# Altium schematic sheet EstmetSquematic.SchDoc(EstmetSquematic)
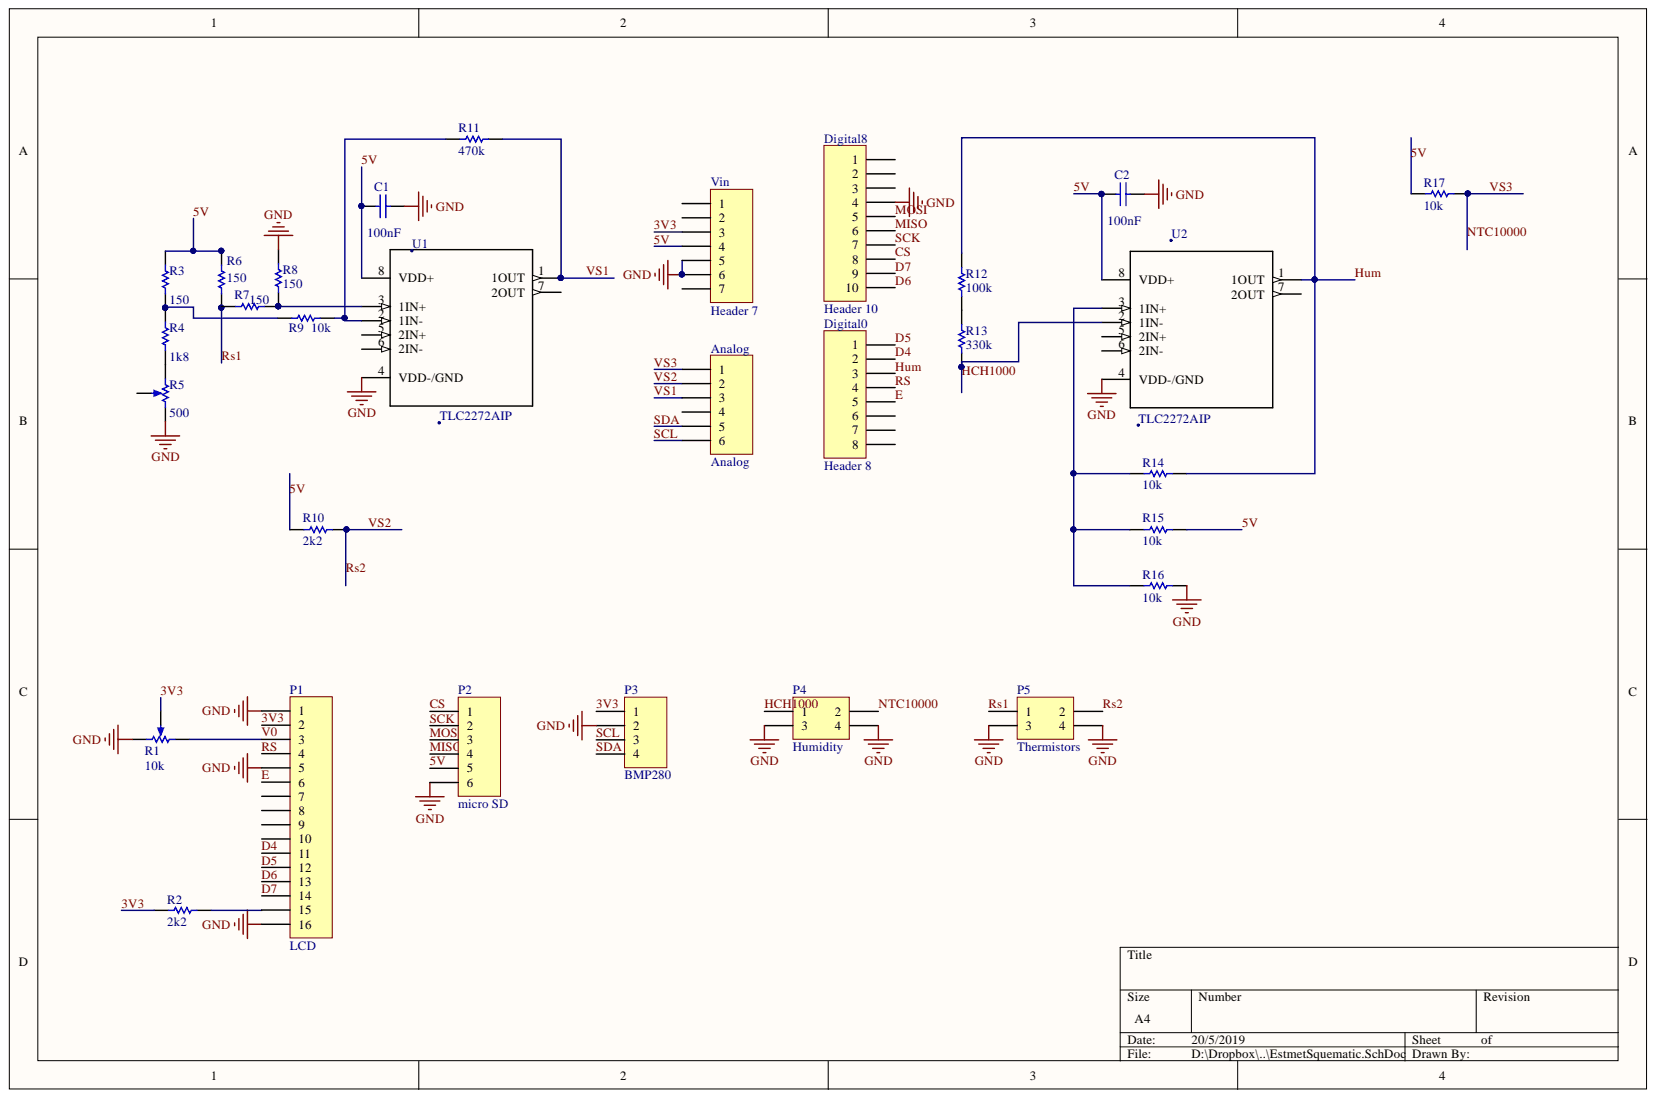
[diagram p0: EstmetSquematic.SchDoc]

## Parts
Analog  Analog  [HDR1X6]
C1  value 100nF  [RAD-0.3]  (hidden comment: Cap)
C2  value 100nF  [RAD-0.3]  (hidden comment: Cap)
Digital0  Header 8  [HDR1X8]
Digital8  Header 10  [HDR1X10]
P1  LCD  [HDR1X16]
P2  micro SD  [HDR1X6]
P3  BMP280  [HDR1X4]
P4  Humidity  [HDR2X2]
P5  Thermistors  [HDR2X2]
R1  value 10k  [VR5]  (hidden comment: RPot)
R2  value 2k2  [AXIAL-0.3]  (hidden comment: Res1)
R3  value 150  [AXIAL-0.3]  (hidden comment: Res1)
R4  value 1k8  [AXIAL-0.3]  (hidden comment: Res1)
R5  value 500  [VR5]  (hidden comment: RPot)
R6  value 150  [AXIAL-0.3]  (hidden comment: Res1)
R7  value 150  [AXIAL-0.3]  (hidden comment: Res1)
R8  value 150  [AXIAL-0.3]  (hidden comment: Res1)
R9  value 10k  [AXIAL-0.3]  (hidden comment: Res1)
R10  value 2k2  [AXIAL-0.3]  (hidden comment: Res1)
R11  value 470k  [AXIAL-0.3]  (hidden comment: Res1)
R12  value 100k  [AXIAL-0.3]  (hidden comment: Res1)
R13  value 330k  [AXIAL-0.3]  (hidden comment: Res1)
R14  value 10k  [AXIAL-0.3]  (hidden comment: Res1)
R15  value 10k  [AXIAL-0.3]  (hidden comment: Res1)
R16  value 10k  [AXIAL-0.3]  (hidden comment: Res1)
R17  value 10k  [AXIAL-0.3]  (hidden comment: Res1)
U1  TLC2272AIP  [*DIP254P762X508-8]
U2  TLC2272AIP  [*DIP254P762X508-8]
Vin  Header 7  [HDR1X7]

## Nets
GND: C1.2 C2.2 Digital8.4 P1.1 P1.5 P1.16 P2.6 P3.2 P4.3 P4.4 P5.3 P5.4 R1.2 R5.2 R8.1 R16.1 U1.4(VDD-/GND) U2.4(VDD-/GND) Vin.5 Vin.6
3V3: P1.2 P3.1 R1.3 R2.2 Vin.3
5V: C1.1 C2.1 P2.5 R3.2 R6.2 R10.2 R15.1 R17.2 U1.8(VDD+) U2.8(VDD+) Vin.4
CS: Digital8.8 P2.1
D4: Digital0.2 P1.11
D5: Digital0.1 P1.12
D6: Digital8.10 P1.13
D7: Digital8.9 P1.14
E: Digital0.5 P1.6
HCH1000: P4.1 R13.2 U2.2(1IN-)
Hum: Digital0.3 R12.1 R14.1 U2.1(1OUT)
MISO: Digital8.6 P2.4
MOSI: Digital8.5 P2.3
NTC10000: Analog.1 P4.2 R17.1
RS: Digital0.4 P1.4
Rs1: P5.1 R6.1 R7.2
Rs2: Analog.2 P5.2 R10.1
SCK: Digital8.7 P2.2
SCL: Analog.6 P3.3
SDA: Analog.5 P3.4
V0: P1.3 R1.1
VS1: Analog.3 R11.1 U1.1(1OUT)
NetP1_15: P1.15 R2.1
NetR3_1: R3.1 R4.2 R9.2
NetR4_1: R4.1 R5.1
NetR7_1: R7.1 R8.2 U1.3(1IN+)
NetR9_1: R9.1 R11.2 U1.2(1IN-)
NetR12_2: R12.2 R13.1
NetR14_2: R14.2 R15.2 R16.2 U2.3(1IN+)

Not connected: Analog 4; Digital0 6 7 8; Digital8 1 2 3; P1 7 8 9 10; R5 3; U1 5(2IN+) 6(2IN-) 7(2OUT); U2 5(2IN+) 6(2IN-) 7(2OUT); Vin 1 2 7

## Library data of the parts
Analog P2 = Header 6: Header, 6-Pin; Signal Integrity: Connector; Library Name: Miscellaneous Connectors.IntLib; LatestRevisionNote: Re-released for DXP Platform.; LatestRevisionDate: 17-Jul-2002; Component Kind: Standard; Publisher: Altium Limited
C1 C2 = Cap: Capacitor; Simulation: CAP; Signal Integrity: Cap; Published: 8-Jun-2000; PackageReference: RAD-0.3; PackageDescription: Radial Cap, Thru-Hole; 2 Leads; 0.3 in Pin Spacing; Library Name: Miscellaneous Devices.IntLib; LatestRevisionNote: Re-released for DXP Platform.; LatestRevisionDate: 17-Jul-2002; Component Kind: Standard; Publisher: Altium Limited
Digital0 = Header 8: Header, 8-Pin; Signal Integrity: Connector; Library Name: Miscellaneous Connectors.IntLib; LatestRevisionNote: Re-released for DXP Platform.; LatestRevisionDate: 17-Jul-2002; Component Kind: Standard; Publisher: Altium Limited
Digital8 = Header 10: Header, 10-Pin; Signal Integrity: Connector; Library Name: Miscellaneous Connectors.IntLib; LatestRevisionNote: Re-released for DXP Platform.; LatestRevisionDate: 17-Jul-2002; Component Kind: Standard; Publisher: Altium Limited
P1 = Header 16: Header, 16-Pin; Signal Integrity: Connector; Library Name: Miscellaneous Connectors.IntLib; LatestRevisionNote: Re-released for DXP Platform.; LatestRevisionDate: 17-Jul-2002; Component Kind: Standard; Publisher: Altium Limited
P3 = Header 4: Header, 4-Pin; Signal Integrity: Connector; Library Name: Miscellaneous Connectors.IntLib; LatestRevisionNote: Re-released for DXP Platform.; LatestRevisionDate: 17-Jul-2002; Component Kind: Standard; Publisher: Altium Limited
P4 P5 = Header 2X2: Header, 2-Pin, Dual row; Signal Integrity: Connector; Library Name: Miscellaneous Connectors.IntLib; LatestRevisionNote: Re-released for DXP Platform.; LatestRevisionDate: 17-Jul-2002; Component Kind: Standard; Publisher: Altium Limited
R1 R5 = RPot: Potentiometer; Sim Note: Enter the Resistance value and the set point into parameter fields.; Set Position: 0.5; Simulation: POT; Published: 8-Jun-2000; PackageReference: VR5; PackageDescription: Variable Resistor, Thru-Hole; 3 Leads; Library Name: Miscellaneous Devices.IntLib; LatestRevisionNote: Re-released for DXP Platform.; LatestRevisionDate: 17-Jul-2002; Component Kind: Standard; Publisher: Altium Limited
R2-R4 R6-R17 = Res1: Resistor; Simulation: RESISTOR; Signal Integrity: Res; Published: 8-Jun-2000; PackageReference: AXIAL-0.3; PackageDescription: Axial Device, Thru-Hole; 2 Leads; 0.3 in Pin Spacing; Library Name: Miscellaneous Devices.IntLib; LatestRevisionNote: Re-released for DXP Platform.; LatestRevisionDate: 17-Jul-2002; Component Kind: Standard; Publisher: Altium Limited
U1 U2 = TLC2272AIP: Advanced LinCMOS_TM_ ail-To- ail Dual Operational Amplifier 8-PDIP; MF: Texas Instruments; SnapEDA_Link: https://www.snapeda.com/parts/TLC2272AIP/Texas%20Instruments/view-part/?ref=snap; Price: None; Package: PDIP-8 Texas Instruments; MP: TLC2272AIP; Library Name: TLC2272AIP.IntLib; Component Kind: Standard; Availability: Unavailable
Vin = Header 7: Header, 7-Pin; Signal Integrity: Connector; Library Name: Miscellaneous Connectors.IntLib; LatestRevisionNote: Re-released for DXP Platform.; LatestRevisionDate: 17-Jul-2002; Component Kind: Standard; Publisher: Altium Limited
